# Altium schematic sheet [05] - PERIPHERAL.SchDoc("[05] - PERIPHERAL")
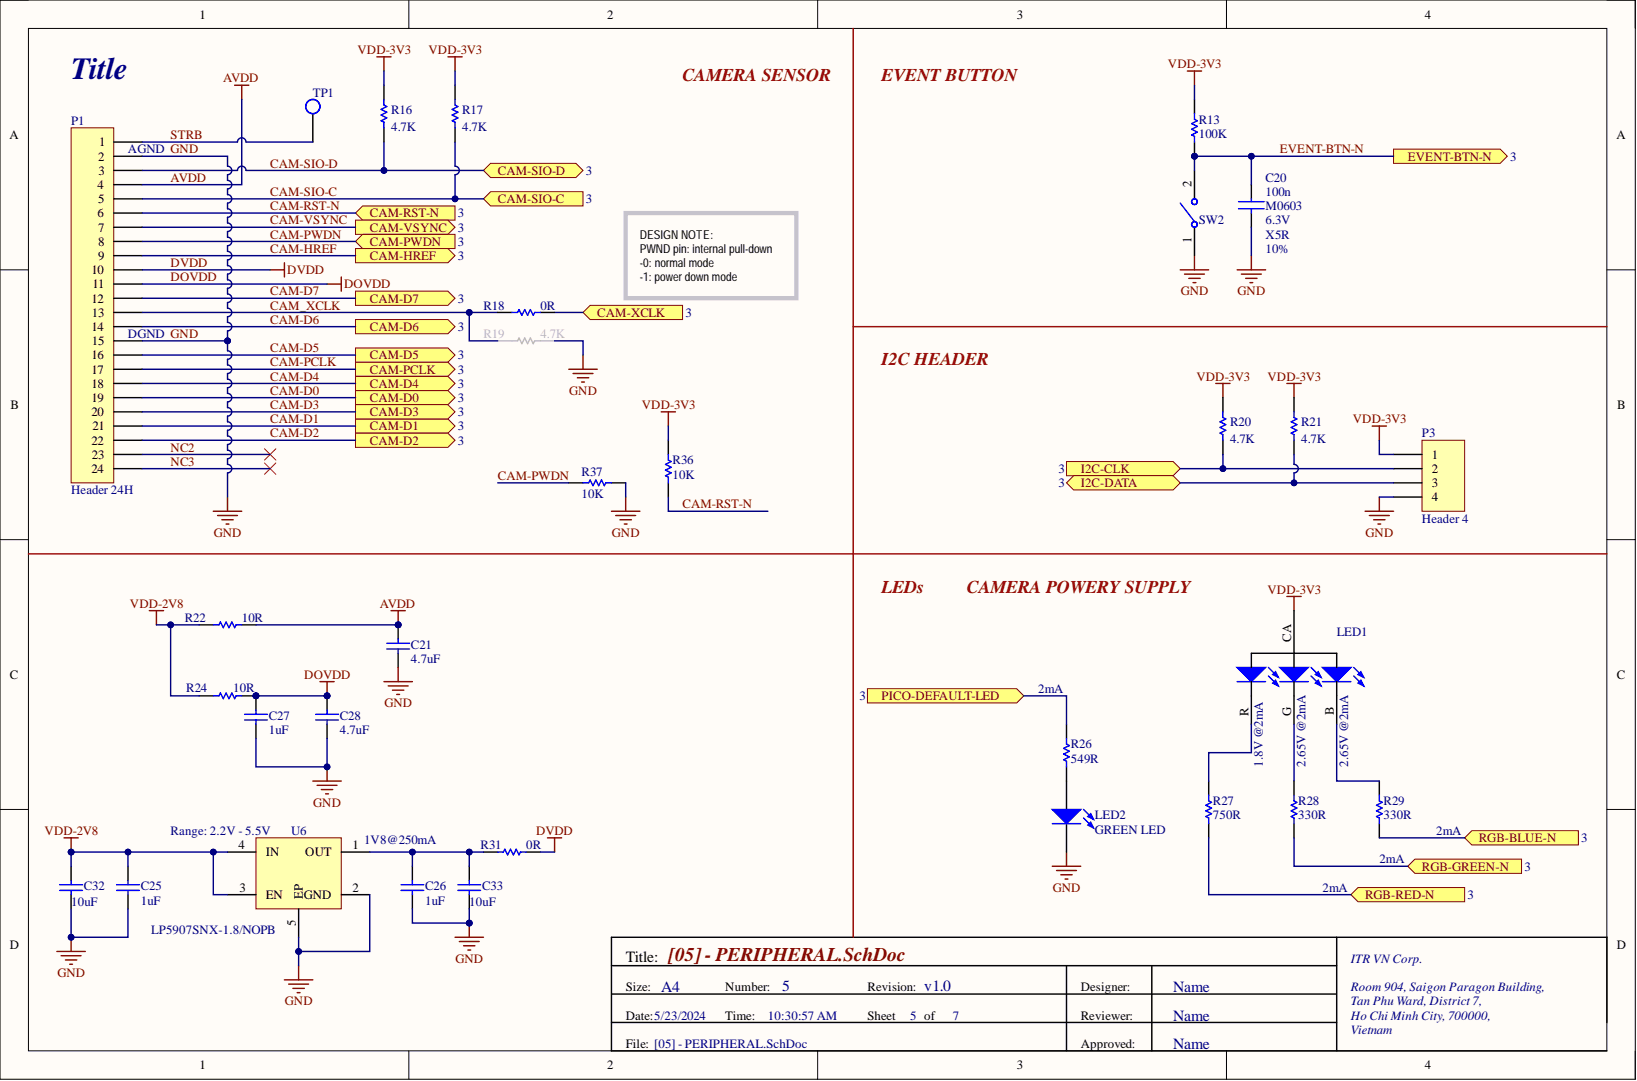
[diagram p0: [05] - PERIPHERAL.SchDoc]

## Parts
C20  100n  Dielectric: X5R  Package: M0603  Tolerance: 10%  Voltage: 6.3V  [CAPC1005X55_0402]
C21  value 4.7uF  Capacitance: 4.7uF  [CAPC1608X80_0603]  (hidden comment: Capacitor)
C25  value 1uF  [CAPC1005X55_0402]  (hidden comment: Capacitor)
C26  value 1uF  [CAPC1005X55_0402]  (hidden comment: Capacitor)
C27  value 1uF  [CAPC1005X55_0402]  (hidden comment: Capacitor)
C28  value 4.7uF  Capacitance: 4.7uF  [CAPC1608X80_0603]  (hidden comment: Capacitor)
C32  value 10uF  Capacitance: 10uF  [CAPC1608X80_0603]  (hidden comment: Capacitor)
C33  value 10uF  Capacitance: 10uF  [CAPC1608X80_0603]  (hidden comment: Capacitor)
LED1  LED (RGB-CA)  [RGB LED View Side]  (value not drawn)
LED2  GREEN LED  [LED-0805-SideView]
P1  Header 24H  [F33Z-1A7Q1-E8C24]
P3  Header 4  [HDR1X4-TP]  (no BOM)
R13  value 100K  [RESC1005X40_0402]  (hidden comment: Resistor)
R16  value 4.7K  [RESC1005X40_0402]  (hidden comment: Resistor)
R17  value 4.7K  [RESC1005X40_0402]  (hidden comment: Resistor)
R18  value 0R  [RESC1005X40_0402]  (hidden comment: Resistor)
R19  value 4.7K  [RESC1005X40_0402]  (hidden comment: Resistor)
R20  value 4.7K  [RESC1005X40_0402]  (hidden comment: Resistor)
R21  value 4.7K  [RESC1005X40_0402]  (hidden comment: Resistor)
R22  value 10R  Resistance: 10R  [RESC1005X40_0402]  (hidden comment: Resistor)
R24  value 10R  Resistance: 10R  [RESC1005X40_0402]  (hidden comment: Resistor)
R26  value 549R  [RESC1005X40_0402]  (hidden comment: Resistor)
R27  value 750R  [RESC1005X40_0402]  (hidden comment: Resistor)
R28  value 330R  Resistance: 330R  [RESC1005X40_0402]  (hidden comment: Resistor)
R29  value 330R  Resistance: 330R  [RESC1005X40_0402]  (hidden comment: Resistor)
R31  value 0R  [RESC1005X40_0402]  (hidden comment: Resistor)
R36  value 10K  [RESC1005X40_0402]  (hidden comment: Resistor)
R37  value 10K  [RESC1005X40_0402]  (hidden comment: Resistor)
SW2  (no value)  [EVP-AKE31A]
TP1  TP  [TEST_PAD-40MIL]  (no BOM)
U6  LP5907SNX-1.8/NOPB  Max Input Voltage: 5.5V  Min Input Voltage: 2.2V  [X2SON-4]

## Ports
CAM-D0, CAM-D1, CAM-D2, CAM-D3, CAM-D4, CAM-D5, CAM-D6, CAM-D7, CAM-HREF, CAM-PCLK, CAM-PWDN, CAM-RST-N, CAM-SIO-C, CAM-SIO-D, CAM-VSYNC, CAM-XCLK, EVENT-BTN-N, I2C-CLK, I2C-DATA, PICO-DEFAULT-LED, RGB-BLUE-N, RGB-GREEN-N, RGB-RED-N

## Nets
VDD-2V8: C25.2 C32.2 R22.1 R24.1 U6.3(EN) U6.4(IN)
VDD-3V3: LED1.CA P3.1 R13.2 R16.2 R17.2 R20.2 R21.2 R36.2
AVDD: C21.2 P1.4 R22.2
CAM-D0: P1.19 ; port CAM-D0
CAM-D1: P1.21 ; port CAM-D1
CAM-D2: P1.22 ; port CAM-D2
CAM-D3: P1.20 ; port CAM-D3
CAM-D4: P1.18 ; port CAM-D4
CAM-D5: P1.16 ; port CAM-D5
CAM-D6: P1.14 ; port CAM-D6
CAM-D7: P1.12 ; port CAM-D7
CAM-HREF: P1.9 ; port CAM-HREF
CAM-PCLK: P1.17 ; port CAM-PCLK
CAM-PWDN: P1.8 R37.2 ; port CAM-PWDN
CAM-RST-N: P1.6 R36.1 ; port CAM-RST-N
CAM-SIO-C: P1.5 R17.1 ; port CAM-SIO-C
CAM-SIO-D: P1.3 R16.1 ; port CAM-SIO-D
CAM-VSYNC: P1.7 ; port CAM-VSYNC
CAM-XCLK: R18.2 ; port CAM-XCLK
CAM_XCLK: P1.13 R18.1 R19.2
DOVDD: C27.2 C28.2 P1.11 R24.2
DVDD: P1.10 R31.2
EVENT-BTN-N: C20.1 R13.1 SW2.2 ; port EVENT-BTN-N
GND: C20.2 C21.1 C25.1 C26.1 C27.1 C28.1 C32.1 C33.1 LED2.2 P1.2 P1.15 P3.4 R19.1 R37.1 SW2.1 U6.2(GND) U6.5(EP)
I2C-CLK: P3.2 R20.1 ; port I2C-CLK
I2C-DATA: P3.3 R21.1 ; port I2C-DATA
NC2: P1.23
NC3: P1.24
PICO-DEFAULT-LED: R26.2 ; port PICO-DEFAULT-LED
RGB-BLUE-N: R29.1 ; port RGB-BLUE-N
RGB-GREEN-N: R28.1 ; port RGB-GREEN-N
RGB-RED-N: R27.1 ; port RGB-RED-N
STRB: P1.1 TP1.1
NetC26_2: C26.2 C33.2 R31.1 U6.1(OUT)
NetLED1_B: LED1.B R29.2
NetLED1_G: LED1.G R28.2
NetLED1_R: LED1.R R27.2
NetLED2_1: LED2.1 R26.1

## Library data of the parts
C20 = CAP_MLCC: Surface Mount Ceramic Multilayer Capacitor 0.1uF -20% to 80% Y5V 16V 0402 Paper T/R; Manufacturer 1: Yageo; Manufacturer Part Number 1: CC0402ZRY5V7BB104; Capacitance: 100nF; Case Code (Imperial): 0402; Case Code (Metric): 1005; Case/Package: 0402; Category: Ceramic Capacitors; Component Kind: Standard; ComponentLink1Description: https://datasheet.ciiva.com/143/mlccorderingcode-143948.pdf?src-supplier=Digi-Key; ComponentLink1URL: https://datasheet.ciiva.com/143/mlccorderingcode-143948.pdf?src-supplier=Digi-Key; ComponentLink2Description: https://datasheet.ciiva.com/143/mlccorderingcode-143947.pdf?src-supplier=Digi-Key; ComponentLink2URL: https://datasheet.ciiva.com/143/mlccorderingcode-143947.pdf?src-supplier=Digi-Key; ComponentLink3Description: https://datasheet.ciiva.com/143/mlccorderingcode-143949.pdf?src-supplier=Digi-Key; ComponentLink3URL: https://datasheet.ciiva.com/143/mlccorderingcode-143949.pdf?src-supplier=Digi-Key; ComponentLink4Description: https://datasheet.ciiva.com/26862/getdatasheetpartid-403483-26862665.pdf?src-supplier=Verical; ComponentLink4URL: https://datasheet.ciiva.com/26862/getdatasheetpartid-403483-26862665.pdf?src-supplier=Verical; ComponentLink5Description: https://datasheet.ciiva.com/7978/1132289-7978122.pdf?src-supplier=Element14; ComponentLink5URL: https://datasheet.ciiva.com/7978/1132289-7978122.pdf?src-supplier=Element14; ComponentLink6Description: https://datasheet.ciiva.com/28450/1132289-28450424.pdf?src-supplier=Element14; ComponentLink6URL: https://datasheet.ciiva.com/28450/1132289-28450424.pdf?src-supplier=Element14; ComponentLink7Description: https://datasheet.ciiva.com/8033/1132289-8033645.pdf?src-supplier=Element14; ComponentLink7URL: https://datasheet.ciiva.com/8033/1132289-8033645.pdf?src-supplier=Element14; ComponentLink8Description: https://datasheet.ciiva.com/8033/1132289-8033647.pdf?src-supplier=Element14; ComponentLink8URL: https://datasheet.ciiva.com/8033/1132289-8033647.pdf?src-supplier=Element14; ComponentLink9Description: https://datasheet.ciiva.com/13386/upy-gphc-y5v-6-3v-to-50v-5-13386335.pdf?src-supplier=Mouser; ComponentLink9URL: https://datasheet.ciiva.com/13386/upy-gphc-y5v-6-3v-to-50v-5-13386335.pdf?src-supplier=Mouser; ComponentLink10Description: https://datasheet.ciiva.com/11586/upy-gphc-y5v-6-3v-to-50v-5-11586519.pdf?src-supplier=Avnet; ComponentLink10URL: https://datasheet.ciiva.com/11586/upy-gphc-y5v-6-3v-to-50v-5-11586519.pdf?src-supplier=Avnet; Depth: 0.5mm; Height: 0.5mm; Lead Free: 5697LeadFree; Length: 1mm; Max Operating Temperature: 85°C; Min Operating Temperature: -30°C; Mount: Surface Mount; Package Quantity: 10000; Packaging: Tape and Reel; Pricing 1: 1=0.1, 10=0.017, 50=0.0092, 100=0.0075, 500=0.00538, 1000=0.00422, 2500=0.00384, 5000=0.00353 (USD); Radiation Hardening: No; Reach SVHC Compliant: No; RoHS: Yes; RoHS Compliant: Yes; Series: CC; Stock 1: 245770; Supplier 1: Digi-Key; Supplier 2: LCSC.com; Supplier Part Number 1: 311-1047-1-ND; Supplier Part Number 2: C106209; Termination: SMD/SMT; Voltage Rating: 16V; Voltage Rating (AC): 16V; Voltage Rating (DC): 16V; Width: 0.5mm
C21 C28 = C_0603: Multilayer Ceramic Capacitor, 4.7 uF, 16 V, ± 10%, X5R, 0603 [1608 Metric]; Manufacturer 1: Samsung; Manufacturer Part Number 1: CL10A475KO8NNNC; Symbol status: Released; Voltage: 16V; Case Code (Imperial): 0603; Case Code (Metric): 1608; Case/Package: 0603; Category: Ceramic Capacitors; Component Kind: Standard; ComponentLink1Description: https://datasheet.ciiva.com/26838/getdatasheetpartid-358619-26838383.pdf?src-supplier=Verical; ComponentLink1URL: https://datasheet.ciiva.com/26838/getdatasheetpartid-358619-26838383.pdf?src-supplier=Verical; ComponentLink2Description: https://datasheet.ciiva.com/25795/cl10a475ko8nnnc-25795890.pdf?src-supplier=Digi-Key; ComponentLink2URL: https://datasheet.ciiva.com/25795/cl10a475ko8nnnc-25795890.pdf?src-supplier=Digi-Key; ComponentLink3Description: https://datasheet.ciiva.com/29198/457663-da-01-en-vs-kondensator-0603-29198671.pdf?src-supplier=Conrad; ComponentLink3URL: https://datasheet.ciiva.com/29198/457663-da-01-en-vs-kondensator-0603-29198671.pdf?src-supplier=Conrad; Construction: Flat; Depth: 0.8mm; Dielectric: X5R; Height: 0.8mm; Length: 1.6mm; Library Name: Miscellaneous_Devices.SchLib; Material: Ceramic; Max Operating Temperature: 85°C; Min Operating Temperature: -55°C; Mount: Surface Mount; Packaging: Tape and Reel; Pricing 1: 1=0.12, 10=0.084, 50=0.0476, 100=0.0394, 500=0.02764, 1000=0.02288 (USD); Radiation Hardening: No; RoHS: Yes; RoHS Compliant: Yes; Series: CL; Stock 1: 1099549; Supplier 1: Digi-Key; Supplier 2: LCSC.com; Supplier Part Number 1: 1276-1784-1-ND; Supplier Part Number 2: C19666; Tolerance: 10%; Voltage Rating: 16V; Voltage Rating (DC): 16V
C25-C27 = Capacitor Semi: 0402 16 V 1 uF X5R ±20% Tolerance SMT Multilayer Ceramic Capacitor; Manufacturer 1: Murata; Manufacturer Part Number 1: GRM155R61C105MA12D; Symbol status: Released; Category: Ceramic Capacitors; Component Kind: Standard; ComponentLink1Description: http://www.mouser.com/ds/2/281/c02e-2905.pdf?src-supplier=Mouser; ComponentLink1URL: http://www.mouser.com/ds/2/281/c02e-2905.pdf?src-supplier=Mouser; ComponentLink2Description: http://search.murata.co.jp/Ceramy/image/img/A01X/G101/ENG/GRM155R61C105MA12-01.pdf?src-supplier=Digi-Key; ComponentLink2URL: http://search.murata.co.jp/Ceramy/image/img/A01X/G101/ENG/GRM155R61C105MA12-01.pdf?src-supplier=Digi-Key; ComponentLink3Description: https://search.murata.co.jp/Ceramy/image/img/A01X/G101/ENG/GRM155R61C105MA12-01.pdf?src-supplier=Digi-Key; ComponentLink3URL: https://search.murata.co.jp/Ceramy/image/img/A01X/G101/ENG/GRM155R61C105MA12-01.pdf?src-supplier=Digi-Key; ComponentLink4Description: https://datasheet.octopart.com/GRM155R61C105MA12D-Murata-datasheet-22122223.pdf?src-supplier=Future+Electronics; ComponentLink4URL: https://datasheet.octopart.com/GRM155R61C105MA12D-Murata-datasheet-22122223.pdf?src-supplier=Future+Electronics; ComponentLink5Description: https://datasheet.octopart.com/GRM155R61C105MA12D-Murata-datasheet-149522996.pdf?src-supplier=Newark; ComponentLink5URL: https://datasheet.octopart.com/GRM155R61C105MA12D-Murata-datasheet-149522996.pdf?src-supplier=Newark; Library Name: Miscellaneous_Devices.SchLib; Pricing 1: 1=0.1, 10=0.031, 50=0.017, 100=0.0138, 500=0.00988, 1000=0.00776, 2500=0.00705, 5000=0.00649 (USD); RoHS: Yes; Stock 1: 217243; Supplier 1: Digi-Key; Supplier 2: LCSC.com; Supplier Part Number 1: 490-14599-1-ND; Supplier Part Number 2: C181017
C32 C33 = Capacitor Semi: Multilayer Ceramic Capacitor, 10 uF, 10 V, ± 20%, X5R, 0603 [1608 Metric]; Manufacturer 1: Murata; Manufacturer Part Number 1: GRM188R61A106ME69D; Voltage Rating: 10V; Case Code (Imperial): 0603; Case Code (Metric): 1608; Case/Package: 0603; Category: Ceramic Capacitors; Component Kind: Standard; ComponentLink1Description: https://datasheet.octopart.com/GRM188R6YA106MA73D-Murata-datasheet-149523261.pdf?src-supplier=Newark; ComponentLink1URL: https://datasheet.octopart.com/GRM188R6YA106MA73D-Murata-datasheet-149523261.pdf?src-supplier=Newark; ComponentLink2Description: http://search.murata.co.jp/Ceramy/image/img/A01X/G101/ENG/GRM188R6YA106MA73-01.pdf?src-supplier=Future+Electronics; ComponentLink2URL: http://search.murata.co.jp/Ceramy/image/img/A01X/G101/ENG/GRM188R6YA106MA73-01.pdf?src-supplier=Future+Electronics; ComponentLink3Description: http://search.murata.co.jp/Ceramy/image/img/A01X/G101/ENG/GRM188R6YA106MA73-01.pdf?src-supplier=Digi-Key; ComponentLink3URL: http://search.murata.co.jp/Ceramy/image/img/A01X/G101/ENG/GRM188R6YA106MA73-01.pdf?src-supplier=Digi-Key; ComponentLink4Description: https://www.murata.com/~/media/webrenewal/support/library/catalog/products/capacitor/mlcc/c02e.ashx?la=en-us&src-supplier=Digi-Key; ComponentLink4URL: https://www.murata.com/~/media/webrenewal/support/library/catalog/products/capacitor/mlcc/c02e.ashx?la=en-us&src-supplier=Digi-Key; ComponentLink5Description: https://datasheet.octopart.com/GRM188R6YA106MA73D-Murata-datasheet-86813743.pdf?src-supplier=Future+Electronics; ComponentLink5URL: https://datasheet.octopart.com/GRM188R6YA106MA73D-Murata-datasheet-86813743.pdf?src-supplier=Future+Electronics; ComponentLink6Description: http://search.murata.co.jp/Ceramy/image/img/A01X/G101/ENG/GRM188R6YA106MA73-01.pdf?src-supplier=Mouser; ComponentLink6URL: http://search.murata.co.jp/Ceramy/image/img/A01X/G101/ENG/GRM188R6YA106MA73-01.pdf?src-supplier=Mouser; ComponentLink7Description: https://datasheet.octopart.com/GRM188R6YA106MA73D-Murata-datasheet-165579666.pdf?src-supplier=TME; ComponentLink7URL: https://datasheet.octopart.com/GRM188R6YA106MA73D-Murata-datasheet-165579666.pdf?src-supplier=TME; ComponentLink8Description: http://search.murata.co.jp/Ceramy/image/img/A01X/G101/ENG/GRM188R6YA106MA73-01.pdf?src-supplier=TTI; ComponentLink8URL: http://search.murata.co.jp/Ceramy/image/img/A01X/G101/ENG/GRM188R6YA106MA73-01.pdf?src-supplier=TTI; ComponentLink9Description: http://www.farnell.com/datasheets/1872210.pdf?src-supplier=Farnell; ComponentLink9URL: http://www.farnell.com/datasheets/1872210.pdf?src-supplier=Farnell; ComponentLink10Description: http://www.mouser.com/ds/2/281/c02e-2905.pdf?src-supplier=Mouser; ComponentLink10URL: http://www.mouser.com/ds/2/281/c02e-2905.pdf?src-supplier=Mouser; ComponentLink11Description: http://www.farnell.com/datasheets/1872210.pdf?src-supplier=Newark; ComponentLink11URL: http://www.farnell.com/datasheets/1872210.pdf?src-supplier=Newark; ComponentLink12Description: https://datasheet.octopart.com/GRM188R61A106ME69D-Murata-datasheet-22122223.pdf?src-supplier=Future+Electronics; ComponentLink12URL: https://datasheet.octopart.com/GRM188R61A106ME69D-Murata-datasheet-22122223.pdf?src-supplier=Future+Electronics; ComponentLink13Description: http://search.murata.co.jp/Ceramy/image/img/A01X/G101/ENG/GRM188R61A106ME69-01.pdf?src-supplier=Digi-Key; ComponentLink13URL: http://search.murata.co.jp/Ceramy/image/img/A01X/G101/ENG/GRM188R61A106ME69-01.pdf?src-supplier=Digi-Key; ComponentLink14Description: http://www.farnell.com/datasheets/2048014.pdf?src-supplier=Element14; ComponentLink14URL: http://www.farnell.com/datasheets/2048014.pdf?src-supplier=Element14; ComponentLink15Description: https://datasheet.octopart.com/GRM188R61A106ME69D-Murata-datasheet-62317187.pdf?src-supplier=Element14; ComponentLink15URL: https://datasheet.octopart.com/GRM188R61A106ME69D-Murata-datasheet-62317187.pdf?src-supplier=Element14; ComponentLink16Description: http://www.farnell.com/datasheets/1872210.pdf?src-supplier=Element14; ComponentLink16URL: http://www.farnell.com/datasheets/1872210.pdf?src-supplier=Element14; ComponentLink17Description: https://datasheet.octopart.com/GRM188R61A106ME69D-Murata-datasheet-165579666.pdf?src-supplier=TME; ComponentLink17URL: https://datasheet.octopart.com/GRM188R61A106ME69D-Murata-datasheet-165579666.pdf?src-supplier=TME; Dielectric: X5R; Height: 0.8mm; Lead Free: Lead Free; Length: 1.6mm; Library Name: Miscellaneous_Devices.SchLib; Material: Ceramic; Max Operating Temperature: 85°C; Min Operating Temperature: -55°C; Mount: Surface Mount; Number of Pins: 2NUMBER; Package Quantity: 4000; Packaging: Tape and Reel; Pricing 1: 1=0.14, 10=0.098, 50=0.0584, 100=0.0459, 500=0.03392, 1000=0.02809 (USD); Reach SVHC Compliant: Unknown; RoHS: Yes; RoHS Compliant: Yes; Series: GRM; Stock 1: 1070569; Supplier 1: Digi-Key; Supplier Part Number 1: 490-10475-1-ND; Termination: SMD/SMT; Thickness: 0.035inch; Tolerance: 20%; Voltage Rating (DC): 10V; Weight: 0.000222oz; Width: 0.8mm
LED1 = LED (RGB-CA): Common Anode RGB LED; Manufacturer 1: Shenzhen Quanxing Electronics Co., Ltd; Manufacturer Part Number 1: CE -1204RGB; Symbol status: Released; Component Kind: Standard; Library Name: Miscellaneous_Devices.SchLib; Supplier 1: https://detail.1688.com/; Supplier Part Number 1: https://shop1465837301069.1688.com/page/creditdetail.htm
LED2 = LED-Side: LED GREEN CLEAR SMD R/A / LED for Side looking special for LCD backlight; Manufacturer 1: Vishay Lite-On; Manufacturer Part Number 1: LTST-S220GKT; Dominant Wavelength: 569nm; Forward Current: 30mA; Forward Voltage: 2.1V; Lens Color: Colorless; Lens Style: Diffused,Colorless,Rectangular,Clear; Luminous Intensity: 10mcd; Number of Elements: 1; Power Dissipation: 100mW; Reverse Voltage: 5V; Test Current: 20mA; Viewing Angle: 130°; Wavelength: 565nm; Case Code (Imperial): 0805; Case Code (Metric): 2012; Case/Package: 0805; Category: LEDs; Color: Green; Component Kind: Standard; ComponentLink1Description: https://datasheet.ciiva.com/2110/ltst-s220gkt-2110016.pdf?src-supplier=Digi-Key; ComponentLink1URL: https://datasheet.ciiva.com/2110/ltst-s220gkt-2110016.pdf?src-supplier=Digi-Key; ComponentLink2Description: https://datasheet.ciiva.com/15118/getdatasheetpartid-221136-15118117.pdf?src-supplier=Verical; ComponentLink2URL: https://datasheet.ciiva.com/15118/getdatasheetpartid-221136-15118117.pdf?src-supplier=Verical; ComponentLink3Description: https://datasheet.ciiva.com/11775/0900766b80dc0b6e-11775442.pdf?src-supplier=Rs; ComponentLink3URL: https://datasheet.ciiva.com/11775/0900766b80dc0b6e-11775442.pdf?src-supplier=Rs; ComponentLink4Description: https://datasheet.ciiva.com/2110/ltst-s220gkt-2110017.pdf?src-supplier=Digi-Key; ComponentLink4URL: https://datasheet.ciiva.com/2110/ltst-s220gkt-2110017.pdf?src-supplier=Digi-Key; ComponentLink5Description: https://datasheet.ciiva.com/16444/ltst-s220gkt-16444773.pdf?src-supplier=Future+Electronics; ComponentLink5URL: https://datasheet.ciiva.com/16444/ltst-s220gkt-16444773.pdf?src-supplier=Future+Electronics; Depth: 0.6mm; Height: 0.6mm; Illumination Color: Green; Lead Free: Lead Free; Length: 2.1mm; Lens Transparency: Clear; Library Name: Pico Camera.SchLib; Max Operating Temperature: 85°C; Min Operating Temperature: -55°C; Mount: Surface Mount; Number of LEDs: 1; Number of Pins: 2; Package Quantity: 1; Packaging: Tape and Reel; Power Rating: 100mW; Pricing 1: 1=0.25, 10=0.134, 100=0.0624, 500=0.05756, 1000=0.0451, 2000=0.04414 (USD); Radiation Hardening: No; RoHS: Yes; RoHS Compliant: Yes; Stock 1: 274681; Supplier 1: Digi-Key; Supplier Part Number 1: 160-1218-1-ND; Width: 1mm
P1 = Header 24H: Conn Ffc Bottom 24POS 0.50MM R/a / Conn Ffc/fpc Connector Skt 24 Pos 0.5MM Solder Ra SMD T/r; Manufacturer 1: Hirose; Manufacturer Part Number 1: FH12-24S-0.5SH(55); Category: FFC / FPC; Component Kind: Standard; ComponentLink1Description: https://datasheet.ciiva.com/15176/getdatasheetpartid-439675-15176853.pdf?src-supplier=Verical; ComponentLink1URL: https://datasheet.ciiva.com/15176/getdatasheetpartid-439675-15176853.pdf?src-supplier=Verical; ComponentLink2Description: https://datasheet.ciiva.com/5137/ed-fh12-20140305-355586-5137161.pdf?src-supplier=Mouser; ComponentLink2URL: https://datasheet.ciiva.com/5137/ed-fh12-20140305-355586-5137161.pdf?src-supplier=Mouser; ComponentLink3Description: https://datasheet.ciiva.com/22697/58803-22697094.pdf?src-supplier=Element14; ComponentLink3URL: https://datasheet.ciiva.com/22697/58803-22697094.pdf?src-supplier=Element14; ComponentLink4Description: https://datasheet.ciiva.com/7661/58803-7661382.pdf?src-supplier=Element14; ComponentLink4URL: https://datasheet.ciiva.com/7661/58803-7661382.pdf?src-supplier=Element14; ComponentLink5Description: https://datasheet.ciiva.com/22630/58803-22630351.pdf?src-supplier=Farnell; ComponentLink5URL: https://datasheet.ciiva.com/22630/58803-22630351.pdf?src-supplier=Farnell; Library Name: Miscellaneous Connectors.SchLib; Pricing 1: 1=2.37, 10=2.065, 25=1.9772, 50=1.9332, 100=1.8453, 250=1.66952, 500=1.53772, 1000=1.31805 (USD); RoHS: Yes; Signal Integrity: Connector; Stock 1: 11613; Supplier 1: Digi-Key; Supplier 2: LCSC.com; Supplier Part Number 1: HFJ124CT-ND; Supplier Part Number 2: C202112
P3 = Header 4: Header, 4-Pin; LatestRevisionDate: 17-Jul-2002; LatestRevisionNote: Re-released for DXP Platform.; Library Name: Miscellaneous Connectors.SchLib; Signal Integrity: Connector; Publisher: Altium Limited
R13 = Resistor: SMD Chip Resistor, 100 kOhm, ± 1%, 63 mW, 0402 [1005 Metric], Thick Film, General Purpose; Manufacturer 1: Yageo; Manufacturer Part Number 1: AC0402FR-07100KL; Max Power Dissipation: 63mW; Power Rating: 62.5mW; Resistance: 100kR; Symbol status: Released; Temperature Coefficient: 100ppm/°C; Voltage Rating: 50V; Case Code (Imperial): 0402; Case Code (Metric): 1005; Case/Package: 0402; Category: Chip SMD Resistors; Component Kind: Standard; ComponentLink1Description: http://www.yageo.com/documents/recent/PYu-AC_51_RoHS_L_2.pdf?src-supplier=Mouser; ComponentLink1URL: http://www.yageo.com/documents/recent/PYu-AC_51_RoHS_L_2.pdf?src-supplier=Mouser; ComponentLink2Description: https://datasheet.octopart.com/AC0402FR-07100KL-Yageo-datasheet-166720450.pdf?src-supplier=Future+Electronics; ComponentLink2URL: https://datasheet.octopart.com/AC0402FR-07100KL-Yageo-datasheet-166720450.pdf?src-supplier=Future+Electronics; ComponentLink3Description: http://www.yageo.com/documents/recent/PYu-AC_51_RoHS_L_2_revised.pdf?src-supplier=Future+Electronics; ComponentLink3URL: http://www.yageo.com/documents/recent/PYu-AC_51_RoHS_L_2_revised.pdf?src-supplier=Future+Electronics; Features: Moisture Resistant,Automotive AEC-Q200; Height: 0.015inch; Lead Free: Lead Free; Length: 0.039inch; Library Name: Miscellaneous_Devices.SchLib; Max Operating Temperature: 155°C; Min Operating Temperature: -55°C; Number of Terminations: 2; Packaging: Tape and Reel; Pricing 1: 1=0.1, 10=0.018, 50=0.011, 100=0.009, 500=0.00606, 1000=0.00517, 5000=0.00371 (USD); RoHS: Yes; RoHS Compliant: Yes; Series: AC; Size Code: 0402; Stock 1: 1750359; Supplier 1: Digi-Key; Supplier 2: LCSC.com; Supplier Part Number 1: YAG3434CT-ND; Supplier Part Number 2: C144809; Termination: SMD/SMT; Tolerance: 1%; Width: 0.5mm
R16 R17 R20 R21 = Res1: SMD Chip Resistor, 4.7 kOhm, ± 1%, 63 mW, 0402 [1005 Metric], Thick Film, General Purpose; Manufacturer 1: Yageo; Manufacturer Part Number 1: RC0402FR-074K7L; Max Power Dissipation: 63mW; Power Rating: 62.5mW; Resistance: 4.7kR; Temperature Coefficient: 100ppm/°C; Voltage Rating: 50V; Case Code (Imperial): 0402; Case Code (Metric): 1005; Case/Package: 0402; Category: Chip SMD Resistors; Component Kind: Standard; ComponentLink1Description: https://datasheet.ciiva.com/22661/1686128-22661342.pdf?src-supplier=Element14; ComponentLink1URL: https://datasheet.ciiva.com/22661/1686128-22661342.pdf?src-supplier=Element14; ComponentLink2Description: https://datasheet.ciiva.com/7850/1018594-7850022.pdf?src-supplier=Element14; ComponentLink2URL: https://datasheet.ciiva.com/7850/1018594-7850022.pdf?src-supplier=Element14; ComponentLink3Description: https://datasheet.ciiva.com/7850/1018594-7850023.pdf?src-supplier=Element14; ComponentLink3URL: https://datasheet.ciiva.com/7850/1018594-7850023.pdf?src-supplier=Element14; ComponentLink4Description: https://datasheet.ciiva.com/15215/getdatasheetpartid-328119-15215035.pdf?src-supplier=Verical; ComponentLink4URL: https://datasheet.ciiva.com/15215/getdatasheetpartid-328119-15215035.pdf?src-supplier=Verical; Construction: Rectangular; Depth: 0.5mm; Features: Moisture Resistant; Lead Free: Lead Free; Length: 1mm; Library Name: Miscellaneous Devices.IntLib; Max Operating Temperature: 155°C; Military Standard: Not; Min Operating Temperature: -55°C; Number of Pins: 2; Number of Terminations: 2; Packaging: Tape and Reel; Pricing 1: 1=0.1, 10=0.015, 50=0.0088, 100=0.0073, 500=0.00488, 1000=0.00417, 5000=0.00297 (USD); Reach SVHC Compliant: No; Resistance Tolerance: 1%; Resistor Type: Thick Film; RoHS: Yes; RoHS Compliant: Yes; Series: RC; Size Code: 0402; Stock 1: 13371034; Supplier 1: Digi-Key; Supplier Part Number 1: 311-4.7KLRCT-ND; Termination: SMD/SMT; Tolerance: 1%; Voltage Rating (AC): 50V; Voltage Rating (DC): 50V; Width: 0.5mm
R18 R31 = Res1: PANASONIC - ERJ2GE0R00X - RES, 0R0, 0.1W, 0402, REEL; Manufacturer Part Number 1: ERJ-2GE0R00X; BOM Type: A; Max Power Dissipation: 100mW; Resistance: 0mR; Temperature Coefficient: 600ppm/°C; Tolerance: 5%; Voltage Rating: 50V; Case Code (Imperial): 0402; Case Code (Metric): 1005; Case/Package: 0402; Category: Chip SMD Resistors; Component Kind: Standard; ComponentLink1Description: https://datasheet.ciiva.com/11821/0900766b80f806dd-11821176.pdf?src-supplier=RSComponents; ComponentLink1URL: https://datasheet.ciiva.com/11821/0900766b80f806dd-11821176.pdf?src-supplier=RSComponents; ComponentLink2Description: https://datasheet.ciiva.com/48531/aoa0000ce1-48531077.pdf?src-supplier=Avnet; ComponentLink2URL: https://datasheet.ciiva.com/48531/aoa0000ce1-48531077.pdf?src-supplier=Avnet; ComponentLink3Description: https://datasheet.ciiva.com/16783/70260739-16783734.pdf?src-supplier=Allied+Electronics; ComponentLink3URL: https://datasheet.ciiva.com/16783/70260739-16783734.pdf?src-supplier=Allied+Electronics; ComponentLink4Description: https://datasheet.ciiva.com/17215/aoa0000ce1-17215386.pdf?src-supplier=Avnet+Europe; ComponentLink4URL: https://datasheet.ciiva.com/17215/aoa0000ce1-17215386.pdf?src-supplier=Avnet+Europe; ComponentLink5Description: https://datasheet.ciiva.com/43460/1678796-43460347.pdf?src-supplier=Farnell; ComponentLink5URL: https://datasheet.ciiva.com/43460/1678796-43460347.pdf?src-supplier=Farnell; ComponentLink6Description: https://datasheet.ciiva.com/5959/1678796-5959692.pdf?src-supplier=Farnell; ComponentLink6URL: https://datasheet.ciiva.com/5959/1678796-5959692.pdf?src-supplier=Farnell; ComponentLink7Description: https://datasheet.ciiva.com/30097/4aoa0000ce1-30097116.pdf?src-supplier=Onlinecomponents.com; ComponentLink7URL: https://datasheet.ciiva.com/30097/4aoa0000ce1-30097116.pdf?src-supplier=Onlinecomponents.com; ComponentLink8Description: https://datasheet.ciiva.com/28695/1678796-28695758.pdf?src-supplier=Element14; ComponentLink8URL: https://datasheet.ciiva.com/28695/1678796-28695758.pdf?src-supplier=Element14; ComponentLink9Description: https://datasheet.ciiva.com/46389/1678796-46389518.pdf?src-supplier=Element14; ComponentLink9URL: https://datasheet.ciiva.com/46389/1678796-46389518.pdf?src-supplier=Element14; Contact Plating: Tin; Depth: 0.5mm; Features: Automotive AEC-Q200; Height: 0.35mm; Lead Free: Lead Free; Length: 1mm; Library Name: Miscellaneous Devices.IntLib; Manufacturer 1: Panasonic; Max Operating Temperature: 155°C; Military Standard: Not; Min Operating Temperature: -55°C; Mount: Surface Mount; Number of Terminations: 2; Package Quantity: 1; Packaging: Tape and Reel; Power Rating: 100mW; Pricing 1: 1=0.10000, 10=0.01900, 25=0.01360, 50=0.01040, 100=0.00770, 250=0.00584, 500=0.00468, 1000=0.00345, 5000=0.00247 (USD); Radiation Hardening: No; REACH SVHC: No SVHC; Resistor Type: Thick Film; RoHS: Yes; Signal Integrity: Res; Simulation: RESISTOR; Stock 1: 15318658; Supplier 1: Digi-Key; Supplier 2: LCSC.com; Supplier Part Number 1: P0.0JCT-ND; Supplier Part Number 2: C242160; Termination: SMD/SMT; Voltage Rating (DC): 50V; Width: 0.02inch
R19 = Res1: Res Thick Film 0402 4.7K Ohm 5% 0.1W(1/10W) +/-200ppm/ßC SMD Automotive T/R; Manufacturer 1: Panasonic; Manufacturer Part Number 1: ERJ-2GEJ472X; Max Power Dissipation: 100mW; Resistance: 4.7kR; Temperature Coefficient: 200ppm/°C; Voltage Rating: 50V; Case Code (Imperial): 0402; Case Code (Metric): 1005; Case/Package: 0402; Category: Chip SMD Resistors; Component Kind: Standard; ComponentLink1Description: https://datasheet.ciiva.com/11821/0900766b80f806dd-11821170.pdf?src-supplier=RSComponents; ComponentLink1URL: https://datasheet.ciiva.com/11821/0900766b80f806dd-11821170.pdf?src-supplier=RSComponents; ComponentLink2Description: https://datasheet.ciiva.com/27055/1935721-27055112.pdf?src-supplier=Jameco; ComponentLink2URL: https://datasheet.ciiva.com/27055/1935721-27055112.pdf?src-supplier=Jameco; ComponentLink3Description: https://datasheet.ciiva.com/17212/aoa0000ce1-17212101.pdf?src-supplier=Avnet+Europe; ComponentLink3URL: https://datasheet.ciiva.com/17212/aoa0000ce1-17212101.pdf?src-supplier=Avnet+Europe; ComponentLink4Description: https://datasheet.ciiva.com/46371/1678796-46371910.pdf?src-supplier=Element14; ComponentLink4URL: https://datasheet.ciiva.com/46371/1678796-46371910.pdf?src-supplier=Element14; ComponentLink5Description: https://datasheet.ciiva.com/24070/aoa0000ce1-37422-24070679.pdf?src-supplier=Mouser; ComponentLink5URL: https://datasheet.ciiva.com/24070/aoa0000ce1-37422-24070679.pdf?src-supplier=Mouser; ComponentLink6Description: https://datasheet.ciiva.com/43460/1678796-43460391.pdf?src-supplier=Farnell; ComponentLink6URL: https://datasheet.ciiva.com/43460/1678796-43460391.pdf?src-supplier=Farnell; ComponentLink7Description: https://datasheet.ciiva.com/16774/70260739-16774504.pdf?src-supplier=Allied+Electronics; ComponentLink7URL: https://datasheet.ciiva.com/16774/70260739-16774504.pdf?src-supplier=Allied+Electronics; ComponentLink8Description: https://datasheet.ciiva.com/11821/0900766b80f806dd-11821328.pdf?src-supplier=Rs; ComponentLink8URL: https://datasheet.ciiva.com/11821/0900766b80f806dd-11821328.pdf?src-supplier=Rs; ComponentLink9Description: https://datasheet.ciiva.com/46377/1678796-46377565.pdf?src-supplier=Element14; ComponentLink9URL: https://datasheet.ciiva.com/46377/1678796-46377565.pdf?src-supplier=Element14; ComponentLink10Description: https://datasheet.ciiva.com/28514/1678796-28514168.pdf?src-supplier=Element14; ComponentLink10URL: https://datasheet.ciiva.com/28514/1678796-28514168.pdf?src-supplier=Element14; ComponentLink11Description: https://datasheet.ciiva.com/16758/70260739-16758341.pdf?src-supplier=Allied+Electronics; ComponentLink11URL: https://datasheet.ciiva.com/16758/70260739-16758341.pdf?src-supplier=Allied+Electronics; ComponentLink12Description: https://datasheet.ciiva.com/16770/70260739-16770309.pdf?src-supplier=Allied+Electronics; ComponentLink12URL: https://datasheet.ciiva.com/16770/70260739-16770309.pdf?src-supplier=Allied+Electronics; Construction: Rectangular; Contact Plating: Tin; Depth: 0.5mm; Features: Automotive AEC-Q200; Height: 0.35mm; Lead Free: Lead Free; Length: 1mm; Library Name: Miscellaneous Devices.IntLib; Max Operating Temperature: 155°C; Military Standard: Not; Min Operating Temperature: -55°C; Mount: Surface Mount; Number of Terminations: 2; Package Quantity: 10000; Packaging: Cut Tape; Power Rating: 100mW; Pricing 1: 1=0.1, 10=0.025, 50=0.0138, 100=0.0101, 500=0.00618, 1000=0.00455, 5000=0.00326 (USD); Radiation Hardening: No; Ratings: AEC-Q200; Reach SVHC Compliant: No; Resistance Tolerance: 5%; Resistor Type: Thick Film; RoHS: Yes; RoHS Compliant: Yes; Series: ERJ; Signal Integrity: Res; Simulation: RESISTOR; Size Code: 0402; Stock 1: 1449846; Supplier 1: Digi-Key; Supplier 2: LCSC.com; Supplier Part Number 1: P4.7KJCT-ND; Supplier Part Number 2: C413001; Technology: THICK FILM; Termination: SMD/SMT; Tolerance: 5%; Voltage Rating (DC): 50V; Width: 0.5mm
R22 R24 = R_0402: Res Thick Film 0402 10 Ohm 5% 1/10W ±200ppm/°C Molded SMD Punched Carrier T/R; Manufacturer 1: Panasonic; Manufacturer Part Number 1: ERJ-2GEJ100X; Max Power Dissipation: 100mW; Symbol status: Released; Voltage Rating: 50V; Case Code (Imperial): 0402; Case Code (Metric): 1005; Case/Package: 0402; Category: Chip SMD Resistors; Component Kind: Standard; ComponentLink1Description: https://datasheet.ciiva.com/34303/2007214-34303754.pdf?src-supplier=Jameco; ComponentLink1URL: https://datasheet.ciiva.com/34303/2007214-34303754.pdf?src-supplier=Jameco; ComponentLink2Description: https://datasheet.ciiva.com/11821/0900766b80f806dd-11821167.pdf?src-supplier=Rs; ComponentLink2URL: https://datasheet.ciiva.com/11821/0900766b80f806dd-11821167.pdf?src-supplier=Rs; ComponentLink3Description: https://datasheet.ciiva.com/28584/1678796-28584087.pdf?src-supplier=Element14; ComponentLink3URL: https://datasheet.ciiva.com/28584/1678796-28584087.pdf?src-supplier=Element14; ComponentLink4Description: https://datasheet.ciiva.com/16778/70260739-16778285.pdf?src-supplier=Allied+Electronics; ComponentLink4URL: https://datasheet.ciiva.com/16778/70260739-16778285.pdf?src-supplier=Allied+Electronics; ComponentLink5Description: https://datasheet.ciiva.com/16199/aoa0000ce1-16199567.pdf?src-supplier=Future+Electronics; ComponentLink5URL: https://datasheet.ciiva.com/16199/aoa0000ce1-16199567.pdf?src-supplier=Future+Electronics; ComponentLink6Description: https://datasheet.ciiva.com/48531/aoa0000ce1-48531078.pdf?src-supplier=Avnet; ComponentLink6URL: https://datasheet.ciiva.com/48531/aoa0000ce1-48531078.pdf?src-supplier=Avnet; ComponentLink7Description: https://datasheet.ciiva.com/30210/4aoa0000ce1-30210518.pdf?src-supplier=Onlinecomponents.com; ComponentLink7URL: https://datasheet.ciiva.com/30210/4aoa0000ce1-30210518.pdf?src-supplier=Onlinecomponents.com; Construction: Rectangular; Contact Plating: Tin; Depth: 0.5mm; Features: Automotive AEC-Q200; Height: 0.35mm; Lead Free: Lead Free; Length: 1mm; Library Name: Miscellaneous_Devices.SchLib; Max Operating Temperature: 155°C; Military Standard: Not; Min Operating Temperature: -55°C; Mount: Surface Mount; Number of Terminations: 2; Package Quantity: 10000; Packaging: Tape and Reel; Power Rating: 100mW; Pricing 1: 1=0.1, 10=0.025, 50=0.0138, 100=0.0101, 500=0.00618, 1000=0.00455, 5000=0.00326 (USD); Radiation Hardening: No; Ratings: AEC-Q200; Reach SVHC Compliant: No; Resistance Tolerance: 5%; Resistor Type: Thick Film; RoHS: Yes; RoHS Compliant: Yes; Series: ERJ; Stock 1: 325048; Supplier 1: Digi-Key; Supplier 2: LCSC.com; Supplier Part Number 1: P10JCT-ND; Supplier Part Number 2: C409845; Technology: THICK FILM; Temperature Coefficient: 200ppm/°C; Termination: SMD/SMT; Tolerance: 5%; Voltage Rating (DC): 50V; Width: 0.5mm; Working Voltage: 50V
R26 = R_0402: SMD Chip Resistor, 549 Ohm, ± 1%, 100 mW, 0402 [1005 Metric], Thick Film, Precision; Manufacturer 1: Panasonic; Manufacturer Part Number 1: ERJ-2RKF5490X; Symbol status: Released; Category: Chip SMD Resistors; Component Kind: Standard; ComponentLink1Description: https://datasheet.ciiva.com/16777/70260748-16777467.pdf?src-supplier=Allied+Electronics; ComponentLink1URL: https://datasheet.ciiva.com/16777/70260748-16777467.pdf?src-supplier=Allied+Electronics; ComponentLink2Description: https://datasheet.ciiva.com/24163/aoa0000ce2-24163725.pdf?src-supplier=Mouser; ComponentLink2URL: https://datasheet.ciiva.com/24163/aoa0000ce2-24163725.pdf?src-supplier=Mouser; ComponentLink3Description: https://datasheet.ciiva.com/46373/1678782-46373382.pdf?src-supplier=Element14; ComponentLink3URL: https://datasheet.ciiva.com/46373/1678782-46373382.pdf?src-supplier=Element14; ComponentLink4Description: https://datasheet.ciiva.com/46373/1678782-46373383.pdf?src-supplier=Element14; ComponentLink4URL: https://datasheet.ciiva.com/46373/1678782-46373383.pdf?src-supplier=Element14; ComponentLink5Description: https://datasheet.ciiva.com/22755/1678782-22755192.pdf?src-supplier=Farnell; ComponentLink5URL: https://datasheet.ciiva.com/22755/1678782-22755192.pdf?src-supplier=Farnell; ComponentLink6Description: https://datasheet.ciiva.com/9181/aoa0000ce2-9181129.pdf?src-supplier=Avnet; ComponentLink6URL: https://datasheet.ciiva.com/9181/aoa0000ce2-9181129.pdf?src-supplier=Avnet; ComponentLink7Description: https://datasheet.ciiva.com/9181/aoa0000ce2-9181133.pdf?src-supplier=Avnet; ComponentLink7URL: https://datasheet.ciiva.com/9181/aoa0000ce2-9181133.pdf?src-supplier=Avnet; ComponentLink8Description: https://datasheet.ciiva.com/43467/1678782-43467286.pdf?src-supplier=Farnell; ComponentLink8URL: https://datasheet.ciiva.com/43467/1678782-43467286.pdf?src-supplier=Farnell; ComponentLink9Description: https://datasheet.ciiva.com/30265/aoa0000ce2-30265222.pdf?src-supplier=Onlinecomponents.com; ComponentLink9URL: https://datasheet.ciiva.com/30265/aoa0000ce2-30265222.pdf?src-supplier=Onlinecomponents.com; Library Name: Miscellaneous_Devices.SchLib; Pricing 1: 1=0.1, 10=0.029, 50=0.0162, 100=0.0119, 500=0.00728, 1000=0.00535, 5000=0.00384 (USD); RoHS: Yes; Stock 1: 261562; Supplier 1: Digi-Key; Supplier 2: LCSC.com; Supplier Part Number 1: P549LCT-ND; Supplier Part Number 2: C3025672
R27 = Resistor: SMD Chip Resistor, 750 Ohm, ± 1%, 63 mW, 0402 [1005 Metric], Thick Film, General Purpose; Manufacturer 1: Yageo; Manufacturer Part Number 1: RC0402FR-07750RL; Symbol status: Released; Category: Chip SMD Resistors; Component Kind: Standard; ComponentLink1Description: https://datasheet.ciiva.com/12667/pyu-rc0402-51-rohs-l-6-r-12667049.pdf?src-supplier=Mouser; ComponentLink1URL: https://datasheet.ciiva.com/12667/pyu-rc0402-51-rohs-l-6-r-12667049.pdf?src-supplier=Mouser; ComponentLink2Description: https://datasheet.ciiva.com/7850/1018594-7850089.pdf?src-supplier=Element14; ComponentLink2URL: https://datasheet.ciiva.com/7850/1018594-7850089.pdf?src-supplier=Element14; ComponentLink3Description: https://datasheet.ciiva.com/7850/1018594-7850090.pdf?src-supplier=Element14; ComponentLink3URL: https://datasheet.ciiva.com/7850/1018594-7850090.pdf?src-supplier=Element14; ComponentLink4Description: https://datasheet.ciiva.com/2553/pyu-r-int-thick-7-2553236.pdf?src-supplier=Digi-Key; ComponentLink4URL: https://datasheet.ciiva.com/2553/pyu-r-int-thick-7-2553236.pdf?src-supplier=Digi-Key; ComponentLink5Description: https://datasheet.ciiva.com/2553/pyu-r-int-thick-7-2553237.pdf?src-supplier=Digi-Key; ComponentLink5URL: https://datasheet.ciiva.com/2553/pyu-r-int-thick-7-2553237.pdf?src-supplier=Digi-Key; ComponentLink6Description: https://datasheet.ciiva.com/2553/pyu-r-int-thick-7-2553238.pdf?src-supplier=Digi-Key; ComponentLink6URL: https://datasheet.ciiva.com/2553/pyu-r-int-thick-7-2553238.pdf?src-supplier=Digi-Key; ComponentLink7Description: https://datasheet.ciiva.com/17196/pyu-rc0402-51-rohs-l-6-17196158.pdf?src-supplier=Future+Electronics; ComponentLink7URL: https://datasheet.ciiva.com/17196/pyu-rc0402-51-rohs-l-6-17196158.pdf?src-supplier=Future+Electronics; Library Name: Miscellaneous_Devices.SchLib; Pricing 1: 1=0.1, 10=0.014, 50=0.0084, 100=0.007, 500=0.00464, 1000=0.00396, 5000=0.00282 (USD); RoHS: Yes; Stock 1: 707716; Supplier 1: Digi-Key; Supplier 2: LCSC.com; Supplier Part Number 1: 311-750LRCT-ND; Supplier Part Number 2: C137936
R28 R29 = Resistor: SMD Chip Resistor, 330 Ohm, ± 1%, 63 mW, 0402 [1005 Metric], Thick Film, General Purpose; Manufacturer 1: Yageo; Manufacturer Part Number 1: RC0402FR-07330RL; Max Power Dissipation: 63mW; Power Rating: 62.5mW; Temperature Coefficient: 100ppm/°C; Voltage Rating: 50V; Case Code (Imperial): 0402; Case Code (Metric): 1005; Case/Package: 0402; Category: Chip SMD Resistors; Component Kind: Standard; Construction: Rectangular; Depth: 0.5mm; Features: Moisture Resistant; Height: 0.016inch; Lead Free: Lead Free; Length: 0.039inch; Library Name: Miscellaneous_Devices.SchLib; Max Operating Temperature: 155°C; Military Standard: Not; Min Operating Temperature: -55°C; Number of Terminations: 2; Package Quantity: 1; Packaging: Tape and Reel; Pricing 1: 1=0.1, 10=0.014, 50=0.0084, 100=0.007, 500=0.00464, 1000=0.00396, 5000=0.00282 (USD); Reach SVHC Compliant: No; Resistance Tolerance: 1%; Resistor Type: Thick Film; RoHS: Yes; RoHS Compliant: Yes; Series: RC; Size Code: 0402; Stock 1: 3960569; Supplier 1: Digi-Key; Supplier 2: LCSC.com; Supplier Part Number 1: 311-330LRCT-ND; Supplier Part Number 2: C105875; Technology: THICK FILM; Termination: SMD/SMT; Tolerance: 1%; Width: 0.02inch
  R28: ComponentLink1Description: https://datasheet.ciiva.com/12667/pyu-rc0402-51-rohs-l-6-r-12667049.pdf?src-supplier=Mouser; ComponentLink1URL: https://datasheet.ciiva.com/12667/pyu-rc0402-51-rohs-l-6-r-12667049.pdf?src-supplier=Mouser; ComponentLink2Description: https://datasheet.ciiva.com/7850/1018594-7850089.pdf?src-supplier=Element14; ComponentLink2URL: https://datasheet.ciiva.com/7850/1018594-7850089.pdf?src-supplier=Element14; ComponentLink3Description: https://datasheet.ciiva.com/7850/1018594-7850090.pdf?src-supplier=Element14; ComponentLink3URL: https://datasheet.ciiva.com/7850/1018594-7850090.pdf?src-supplier=Element14; ComponentLink4Description: https://datasheet.ciiva.com/2553/pyu-r-int-thick-7-2553236.pdf?src-supplier=Digi-Key; ComponentLink4URL: https://datasheet.ciiva.com/2553/pyu-r-int-thick-7-2553236.pdf?src-supplier=Digi-Key; ComponentLink5Description: https://datasheet.ciiva.com/2553/pyu-r-int-thick-7-2553237.pdf?src-supplier=Digi-Key; ComponentLink5URL: https://datasheet.ciiva.com/2553/pyu-r-int-thick-7-2553237.pdf?src-supplier=Digi-Key; ComponentLink6Description: https://datasheet.ciiva.com/2553/pyu-r-int-thick-7-2553238.pdf?src-supplier=Digi-Key; ComponentLink6URL: https://datasheet.ciiva.com/2553/pyu-r-int-thick-7-2553238.pdf?src-supplier=Digi-Key; ComponentLink7Description: https://datasheet.ciiva.com/17196/pyu-rc0402-51-rohs-l-6-17196158.pdf?src-supplier=Future+Electronics; ComponentLink7URL: https://datasheet.ciiva.com/17196/pyu-rc0402-51-rohs-l-6-17196158.pdf?src-supplier=Future+Electronics; ComponentLink8Description: https://datasheet.ciiva.com/11819/0900766b80c66297-11819490.pdf?src-supplier=Rs; ComponentLink8URL: https://datasheet.ciiva.com/11819/0900766b80c66297-11819490.pdf?src-supplier=Rs; ComponentLink9Description: https://datasheet.ciiva.com/2453/pyu-r-int-thick-7-2453662.pdf?src-supplier=Digi-Key; ComponentLink9URL: https://datasheet.ciiva.com/2453/pyu-r-int-thick-7-2453662.pdf?src-supplier=Digi-Key; ComponentLink10Description: https://datasheet.ciiva.com/2453/pyu-r-int-thick-7-2453663.pdf?src-supplier=Digi-Key; ComponentLink10URL: https://datasheet.ciiva.com/2453/pyu-r-int-thick-7-2453663.pdf?src-supplier=Digi-Key; ComponentLink11Description: https://datasheet.ciiva.com/2453/pyu-r-int-thick-7-2453661.pdf?src-supplier=Digi-Key; ComponentLink11URL: https://datasheet.ciiva.com/2453/pyu-r-int-thick-7-2453661.pdf?src-supplier=Digi-Key; ComponentLink12Description: https://datasheet.ciiva.com/12656/pyu-rc0402-51-rohs-l-6-r-12656815.pdf?src-supplier=Mouser; ComponentLink12URL: https://datasheet.ciiva.com/12656/pyu-rc0402-51-rohs-l-6-r-12656815.pdf?src-supplier=Mouser; ComponentLink13Description: https://datasheet.ciiva.com/7849/1018594-7849963.pdf?src-supplier=Element14; ComponentLink13URL: https://datasheet.ciiva.com/7849/1018594-7849963.pdf?src-supplier=Element14; ComponentLink14Description: https://datasheet.ciiva.com/7849/1018594-7849962.pdf?src-supplier=Element14; ComponentLink14URL: https://datasheet.ciiva.com/7849/1018594-7849962.pdf?src-supplier=Element14; ComponentLink15Description: https://datasheet.ciiva.com/17083/pyu-rc0402-51-rohs-l-6-r-17083994.pdf?src-supplier=Future+Electronics; ComponentLink15URL: https://datasheet.ciiva.com/17083/pyu-rc0402-51-rohs-l-6-r-17083994.pdf?src-supplier=Future+Electronics
  R29: ComponentLink1Description: https://datasheet.ciiva.com/11819/0900766b80c66297-11819490.pdf?src-supplier=Rs; ComponentLink1URL: https://datasheet.ciiva.com/11819/0900766b80c66297-11819490.pdf?src-supplier=Rs; ComponentLink2Description: https://datasheet.ciiva.com/2453/pyu-r-int-thick-7-2453662.pdf?src-supplier=Digi-Key; ComponentLink2URL: https://datasheet.ciiva.com/2453/pyu-r-int-thick-7-2453662.pdf?src-supplier=Digi-Key; ComponentLink3Description: https://datasheet.ciiva.com/2453/pyu-r-int-thick-7-2453663.pdf?src-supplier=Digi-Key; ComponentLink3URL: https://datasheet.ciiva.com/2453/pyu-r-int-thick-7-2453663.pdf?src-supplier=Digi-Key; ComponentLink4Description: https://datasheet.ciiva.com/2453/pyu-r-int-thick-7-2453661.pdf?src-supplier=Digi-Key; ComponentLink4URL: https://datasheet.ciiva.com/2453/pyu-r-int-thick-7-2453661.pdf?src-supplier=Digi-Key; ComponentLink5Description: https://datasheet.ciiva.com/12656/pyu-rc0402-51-rohs-l-6-r-12656815.pdf?src-supplier=Mouser; ComponentLink5URL: https://datasheet.ciiva.com/12656/pyu-rc0402-51-rohs-l-6-r-12656815.pdf?src-supplier=Mouser; ComponentLink6Description: https://datasheet.ciiva.com/7849/1018594-7849963.pdf?src-supplier=Element14; ComponentLink6URL: https://datasheet.ciiva.com/7849/1018594-7849963.pdf?src-supplier=Element14; ComponentLink7Description: https://datasheet.ciiva.com/7849/1018594-7849962.pdf?src-supplier=Element14; ComponentLink7URL: https://datasheet.ciiva.com/7849/1018594-7849962.pdf?src-supplier=Element14; ComponentLink8Description: https://datasheet.ciiva.com/17083/pyu-rc0402-51-rohs-l-6-r-17083994.pdf?src-supplier=Future+Electronics; ComponentLink8URL: https://datasheet.ciiva.com/17083/pyu-rc0402-51-rohs-l-6-r-17083994.pdf?src-supplier=Future+Electronics
R36 R37 = Res1: RES SMD 10K OHM 5% 1/10W 0402; Manufacturer 1: Panasonic; Manufacturer Part Number 1: ERJ-2GEJ103X; BOM Type: A; Max Power Dissipation: 100mW; Resistance: 10kR; Temperature Coefficient: 200ppm/°C; Voltage Rating: 50V; Case Code (Imperial): 0402; Case Code (Metric): 1005; Case/Package: 0402; Category: Chip SMD Resistors; Component Kind: Standard; ComponentLink1Description: https://datasheet.ciiva.com/11821/0900766b80f806dd-11821170.pdf?src-supplier=RSComponents; ComponentLink1URL: https://datasheet.ciiva.com/11821/0900766b80f806dd-11821170.pdf?src-supplier=RSComponents; ComponentLink2Description: https://datasheet.ciiva.com/27055/1935721-27055112.pdf?src-supplier=Jameco; ComponentLink2URL: https://datasheet.ciiva.com/27055/1935721-27055112.pdf?src-supplier=Jameco; ComponentLink3Description: https://datasheet.ciiva.com/17212/aoa0000ce1-17212101.pdf?src-supplier=Avnet+Europe; ComponentLink3URL: https://datasheet.ciiva.com/17212/aoa0000ce1-17212101.pdf?src-supplier=Avnet+Europe; ComponentLink4Description: https://datasheet.ciiva.com/46371/1678796-46371910.pdf?src-supplier=Element14; ComponentLink4URL: https://datasheet.ciiva.com/46371/1678796-46371910.pdf?src-supplier=Element14; ComponentLink5Description: https://datasheet.ciiva.com/24070/aoa0000ce1-37422-24070679.pdf?src-supplier=Mouser; ComponentLink5URL: https://datasheet.ciiva.com/24070/aoa0000ce1-37422-24070679.pdf?src-supplier=Mouser; ComponentLink6Description: https://datasheet.ciiva.com/43460/1678796-43460391.pdf?src-supplier=Farnell; ComponentLink6URL: https://datasheet.ciiva.com/43460/1678796-43460391.pdf?src-supplier=Farnell; ComponentLink7Description: https://datasheet.ciiva.com/16774/70260739-16774504.pdf?src-supplier=Allied+Electronics; ComponentLink7URL: https://datasheet.ciiva.com/16774/70260739-16774504.pdf?src-supplier=Allied+Electronics; Contact Plating: Tin; Depth: 0.5mm; Features: Automotive AEC-Q200; Height: 0.35mm; LatestRevisionDate: 17-Jul-2002; LatestRevisionNote: Re-released for DXP Platform.; Lead Free: Lead Free; Length: 1mm; Library Name: Miscellaneous Devices.IntLib; Max Operating Temperature: 155°C; Military Standard: Not; Min Operating Temperature: -55°C; Mount: Surface Mount; Number of Terminations: 2; Package Quantity: 1; PackageDescription: Axial Device, Thru-Hole; 2 Leads; 0.3 in Pin Spacing; PackageReference: AXIAL-0.3; Packaging: Cut Tape; Power Rating: 100mW; Pricing 1: 1=0.10000, 10=0.01900, 100=0.00770, 1000=0.00345, 2500=0.00299, 5000=0.00247 (USD); Published: 8-Jun-2000; Radiation Hardening: No; REACH SVHC: No SVHC; Resistor Type: Thick Film; RoHS: Yes; Signal Integrity: Res; Simulation: RESISTOR; Stock 1: 2048955; Supplier 1: Digi-Key; Supplier 2: LCSC.com; Supplier Part Number 1: P10KJCT-ND; Supplier Part Number 2: C278577; Termination: SMD/SMT; Tolerance: 5%; Voltage Rating (DC): 50V; Width: 0.02inch; Publisher: Altium Limited
SW2 = SPDT Toggle Switch 1: 3.8MM X 1.9MM / 1.6N / 0.12MM ST; Manufacturer 1: Panasonic; Illumination Type: Non-Illuminated; Mechanical Life: 500000Cycles; Operating Force: 160gf; Ratings: IP67,Waterproof,Dust Tight; Switch Function: Off-Mom; Symbol status: Released; Throw Configuration: SPST; Voltage Rating: 15V; Component Kind: Standard; ComponentLink1Description: https://industrial.panasonic.com/ac/cdn/e/control/switch/light-touch/catalog/sw_lt_eng_3819s_side.pdf?src-supplier=Digi-Key; ComponentLink1URL: https://industrial.panasonic.com/ac/cdn/e/control/switch/light-touch/catalog/sw_lt_eng_3819s_side.pdf?src-supplier=Digi-Key; Library Name: Miscellaneous_Devices.SchLib; Manufacturer Part Number 1: EVP-AKE31A; Max Operating Temperature: 85°C; Min Operating Temperature: -40°C; Mount: Surface Mount; Package / Case: SMT; Packaging: Cut Tape; Pricing 1: 1=0.61000, 10=0.58500, 25=0.56360, 100=0.48760, 250=0.44424, 500=0.41174, 1000=0.36839, 2500=0.34889 (USD); RoHS: No; Stock 1: 9109; Supplier 1: Digi-Key; Supplier Part Number 1: P123437CT-ND; Switch Travel: 0.12mm; Termination: SMD/SMT
TP1 = TP_1: Library Name: Miscellaneous_Devices.SchLib
U6 = LP5907SNX-1.8/NOPB: IC REG LINEAR 1.8V 250MA 4X2SON; Manufacturer 1: Texas Instruments; Accuracy: 2%; Dropout Voltage: 120mV; Max Supply Current: 425uA; Number of Outputs: 1; Output Configuration: Positive; Output Type: Fixed; Power Supply Rejection Ratio (PSRR): 60dB; Quiescent Current: 25uA; Category: Voltage Regulators - Linear; Component Kind: Standard; ComponentLink1Description: https://datasheet.ciiva.com/30016/lp5907-30016217.pdf?src-supplier=Avnet; ComponentLink1URL: https://datasheet.ciiva.com/30016/lp5907-30016217.pdf?src-supplier=Avnet; ComponentLink2Description: https://datasheet.ciiva.com/15083/lp5907-15083829.pdf?src-supplier=Digi-Key; ComponentLink2URL: https://datasheet.ciiva.com/15083/lp5907-15083829.pdf?src-supplier=Digi-Key; Lead Free: Lead Free; Manufacturer Part Number 1: LP5907SNX-1.8/NOPB; Max Operating Temperature: 125°C; Max Output Current: 250mA; Min Operating Temperature: -40°C; Min Output Voltage: 1.8V; Mount: Surface Mount; Nominal Output Voltage: 1.8V; Number of Pins: 4; Number of Regulators: 1; Output Current: 250mA; Output Voltage: 1.8V; Output Voltage Accuracy: 2%; Packaging: Tape and Reel; Polarity: Positive; Pricing 1: 1=0.69, 10=0.592, 25=0.5528, 100=0.4422, 250=0.41064, 500=0.34746, 1000=0.2685 (USD); Radiation Hardening: No; Reach SVHC Compliant: No; RoHS: Yes; RoHS Compliant: Yes; Series: -; Stock 1: 88440; Supplier 1: Digi-Key; Supplier Part Number 1: 296-40369-1-ND; Tolerance: 2%; Library Name: CRELY PRO GEN6 V1.0.SCHLIB
